# Altium schematic sheet StepMotor4.SchDoc
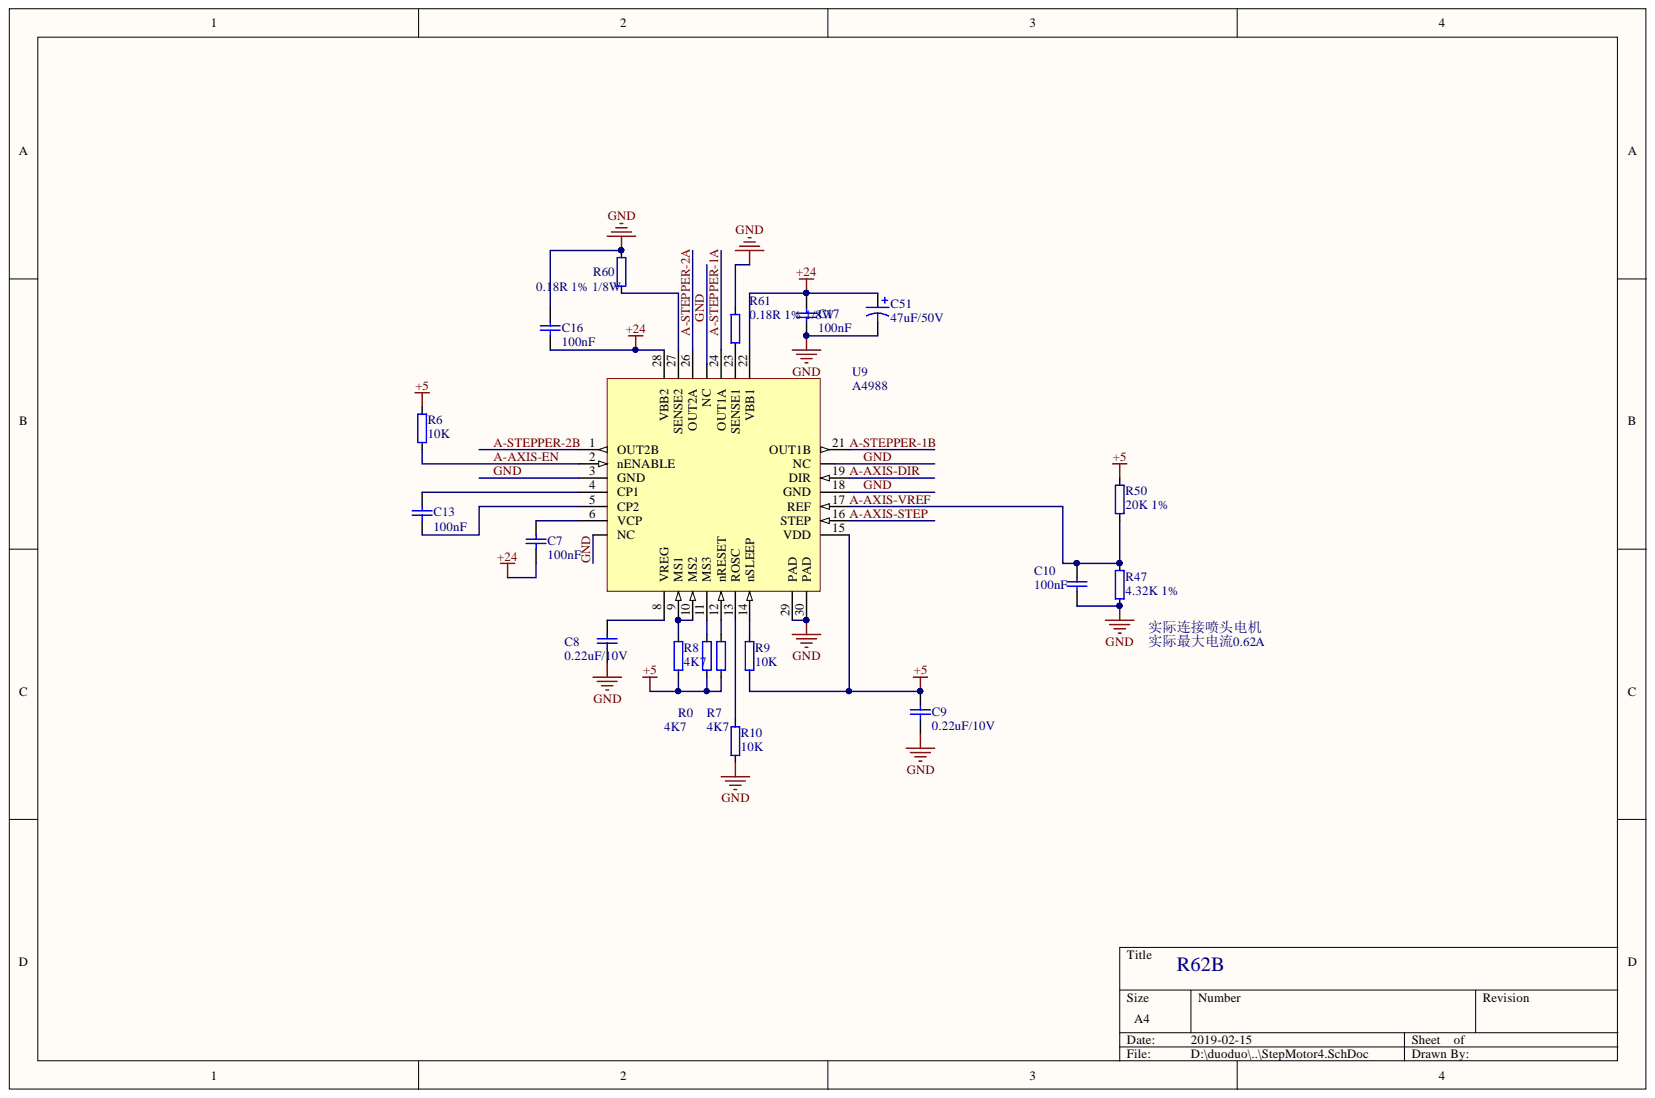
[diagram p0: StepMotor4.SchDoc]

## Parts
C7  100nF  [0603]
C8  0.22uF/10V  [0603]
C9  0.22uF/10V  [0603]
C10  100nF  [0603]
C13  100nF  [0603]
C16  100nF  [0603]
C17  100nF  [0603]
C51  47uF/50V  [EC-6.3]
R0  4K7  [0603]
R6  10K  [0603]
R7  4K7  [0603]
R8  4K7  [0603]
R9  10K  [0603]
R10  10K  [0603]
R47  4.32K 1%  [0603]
R50  20K 1%  [0603]
R60  0.18R 1% 1/8W  [0805]
R61  0.18R 1% 1/8W  [0805]
U9  A4988  [QFN-28]

## Nets
+5: C9.1 R0.2 R6.2 R7.2 R8.2 R9.2 R50.2 U9.15(VDD)
+24: C7.2 C16.2 C17.2 C51.1 U9.22(VBB1) U9.28(VBB2)
A-AXIS-DIR: U9.19(DIR)
A-AXIS-EN: R6.1 U9.2(nENABLE)
A-AXIS-STEP: U9.16(STEP)
A-AXIS-VREF: C10.1 R47.1 R50.1 U9.17(REF)
A-STEPPER-1A: U9.24(OUT1A)
A-STEPPER-1B: U9.21(OUT1B)
A-STEPPER-2A: U9.26(OUT2A)
A-STEPPER-2B: U9.1(OUT2B)
GND: C8.2 C9.2 C10.2 C16.1 C17.1 C51.2 R10.2 R47.2 R60.2 R61.2 U9.3(GND) U9.7(NC) U9.18(GND) U9.20(NC) U9.25(NC) U9.29(PAD) U9.30(PAD)
NetC7_1: C7.1 U9.6(VCP)
NetC8_1: C8.1 U9.8(VREG)
NetC13_1: C13.1 U9.4(CP1)
NetC13_2: C13.2 U9.5(CP2)
NetR0_1: R0.1 U9.11(MS3)
NetR7_1: R7.1 U9.12(nRESET)
NetR8_1: R8.1 U9.9(MS1) U9.10(MS2)
NetR9_1: R9.1 U9.14(nSLEEP)
NetR10_1: R10.1 U9.13(ROSC)
NetR60_1: R60.1 U9.27(SENSE2)
NetR61_1: R61.1 U9.23(SENSE1)

## Library data of the parts
C7-C10 C13 C16 C17 = CAP: Capacitor; Component Kind: Standard; Library Name: DMSch.SCHLIB
C51 = Cap Pol1: Polarized Capacitor (Radial); Component Kind: Standard; Library Name: Miscellaneous Devices.IntLib; Signal Integrity: Cap Pol; Simulation: CAP
R0 R6-R10 R47 R50 R60 R61 = RES3: Component Kind: Standard; Library Name: DMSch.SCHLIB
U9 = A4988-QFN28: A4982 Step Driver; Component Kind: Standard; Library Name: DMSch.SCHLIB
